# Altium schematic sheet 1_power.SchDoc(1_power)
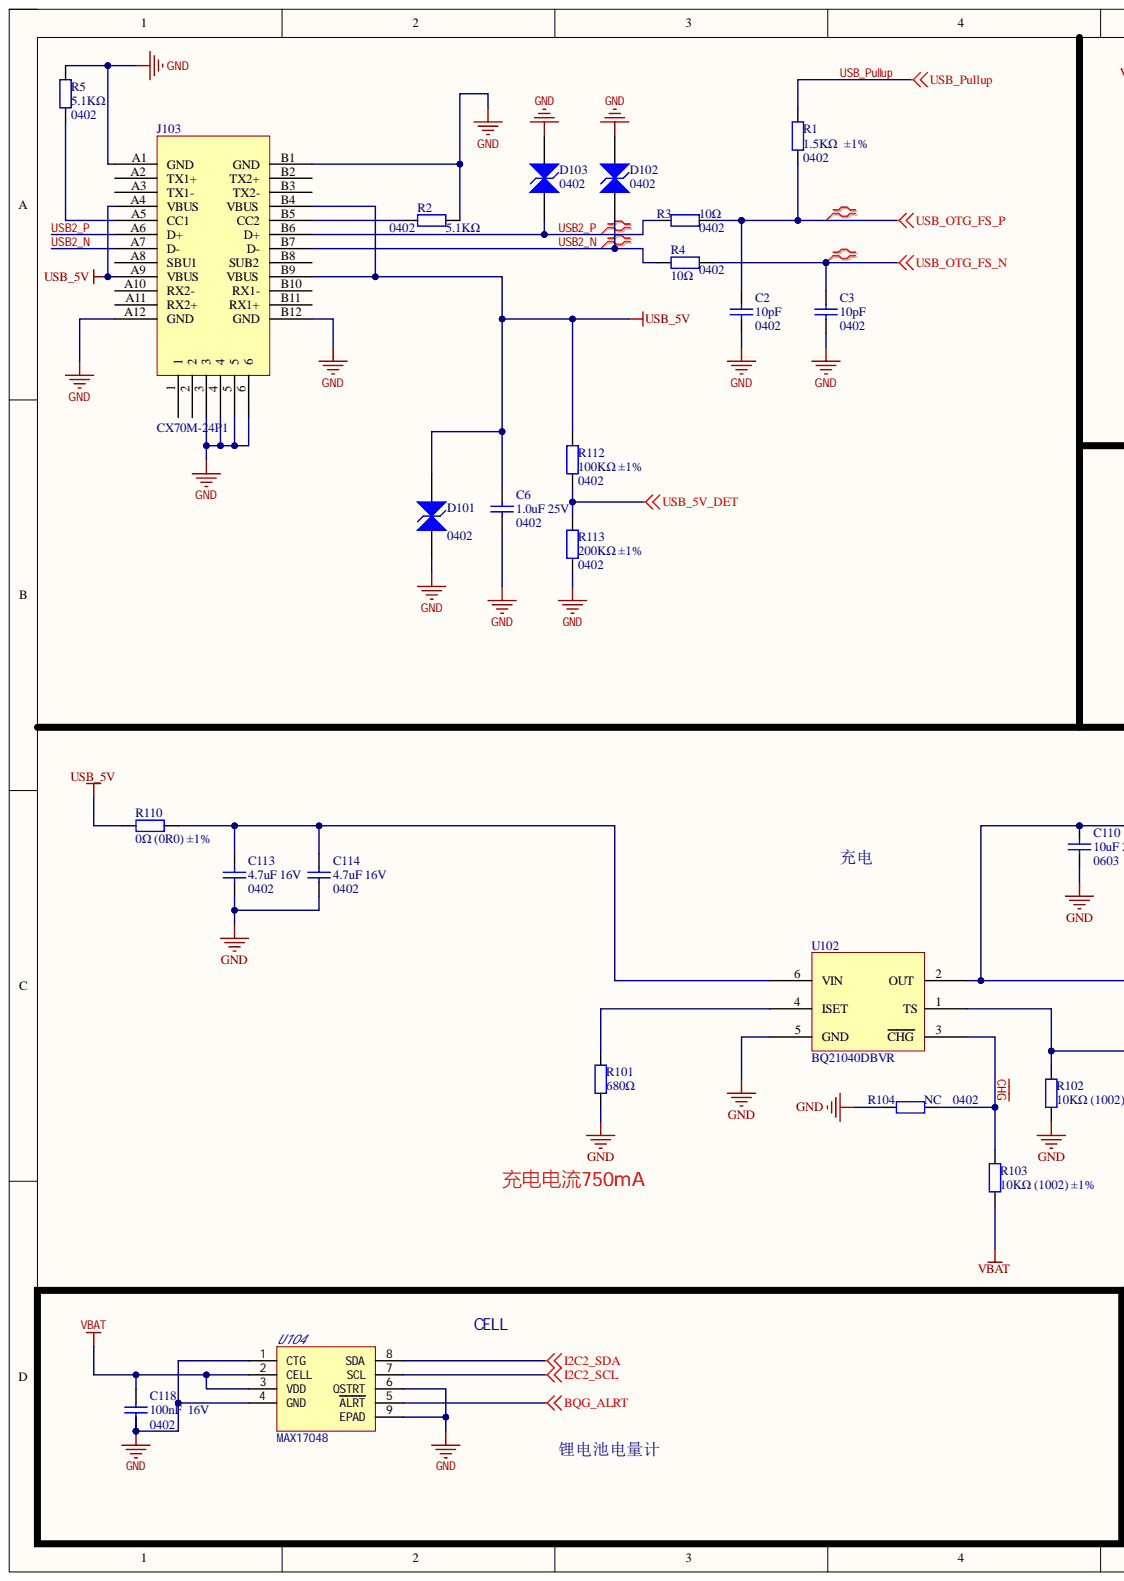
[diagram p0: 1_power.SchDoc, left]
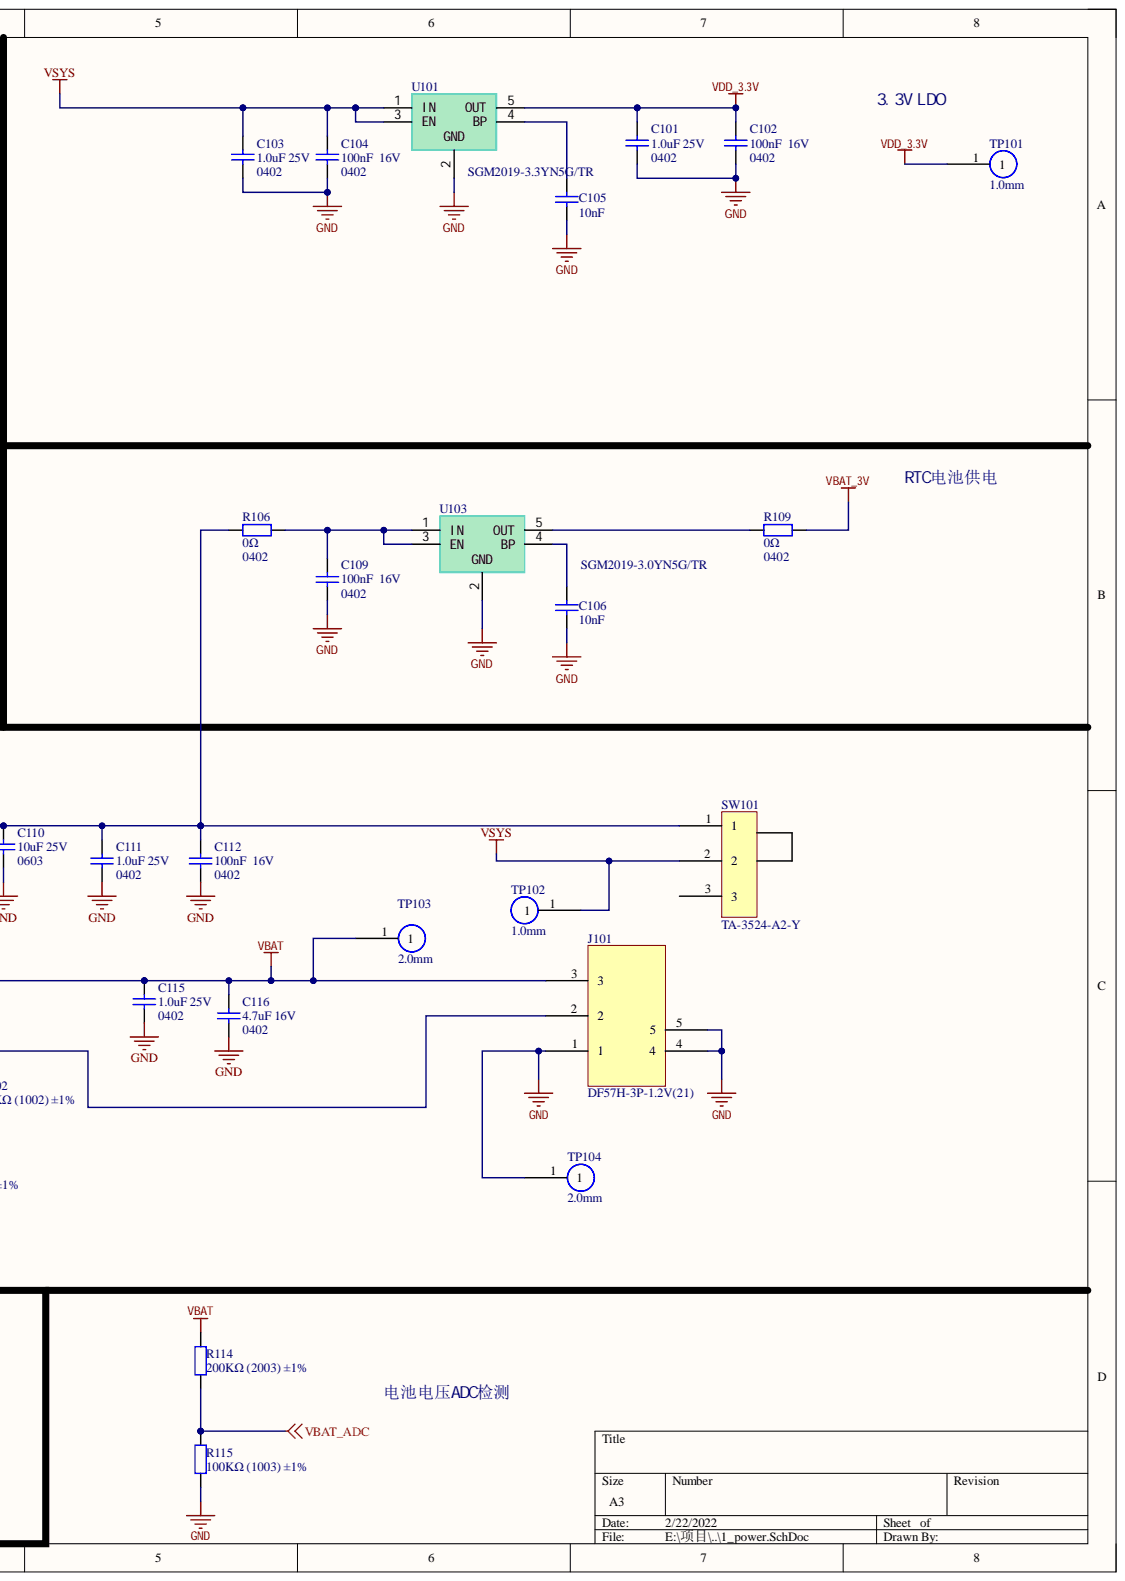
[diagram p1: 1_power.SchDoc, right]

## Parts
C2  10pF  Package: 0402  [0402_C]
C3  10pF  Package: 0402  [0402_C]
C6  1.0uF 25V  Package: 0402  [0402_C]
C101  1.0uF 25V  Package: 0402  [0402_C]
C102  100nF  16V  Package: 0402  [0402_C]
C103  1.0uF 25V  Package: 0402  [0402_C]
C104  100nF  16V  Package: 0402  [0402_C]
C105  10nF  [0402_C]
C106  10nF  [0402_C]
C109  100nF  16V  Package: 0402  [0402_C]
C110  10uF 25V  Package: 0603  [0603_C]
C111  1.0uF 25V  Package: 0402  [0402_C]
C112  100nF  16V  Package: 0402  [0402_C]
C113  4.7uF 16V  Package: 0402  [0402_C]
C114  4.7uF 16V  Package: 0402  [0402_C]
C115  1.0uF 25V  Package: 0402  [0402_C]
C116  4.7uF 16V  Package: 0402  [0402_C]
C118  100nF  16V  Package: 0402  [0402_C]
D101  Package: 0402  [DFN1006]  (hidden comment: RCLAMP0521P-N)
D102  Package: 0402  [DFN1006]  (hidden comment: RCLAMP0521P-N)
D103  Package: 0402  [DFN1006]  (hidden comment: RCLAMP0521P-N)
J101  DF57H-3P-1.2V(21)  [DF57H-3P-1.2V(21)]
J103  CX70M-24P1  [CX70M-24P1]
R1  1.5KΩ  ±1%  Package: 0402  [0402_R]
R2  5.1KΩ  Package: 0402  [0402_R]
R3  10Ω  Package: 0402  [0402_R]
R4  10Ω  Package: 0402  [0402_R]
R5  5.1KΩ  Package: 0402  [0402_R]
R101  680Ω  [0402_R]
R102  10KΩ (1002) ±1%  [0402_R]
R103  10KΩ (1002) ±1%  [0402_R]
R104  NC  Package: 0402  [0402_R]
R106  0Ω  Package: 0402  [0402_R]
R109  0Ω  Package: 0402  [0402_R]
R110  0Ω (0R0) ±1%  [0603_R]
R112  100KΩ ±1%  Package: 0402  [0402_R]
R113  200KΩ ±1%  Package: 0402  [0402_R]
R114  200KΩ (2003) ±1%  [0402_R]
R115  100KΩ (1003) ±1%  [0402_R]
SW101  TA-3524-A2-Y  [TA-3524-A2-Y]
TP101  1.0mm  [1mm测试点]
TP102  1.0mm  [1mm测试点]
TP103  2.0mm  [2mm测试点]
TP104  2.0mm  [2mm测试点]
U101  SGM2019-3.3YN5G/TR  [SGM2019-3.3YN5G/TR]
U102  BQ21040DBVR  [BQ21040DBVR]
U103  SGM2019-3.0YN5G/TR  [SGM2019-3.0YN5G/TR]
U104  MAX17048  [TDFN-10_EP]

## Nets
BQG_ALRT: U104.5(ALRT)
GND: C2.1 C3.1 C6.1 C101.1 C102.1 C103.1 C104.1 C105.1 C106.1 C109.1 C110.1 C111.1 C112.1 C113.1 C114.1 C115.1 C116.1 C118.1 D101.1 D102.1 D103.1 J101.1 J101.4 J101.5 J103.3 J103.4 J103.5 J103.6 J103.A1(GND) J103.A12(GND) J103.B1(GND) J103.B12(GND) R2.2 R5.2 R101.1 R102.1 R104.1 R113.1 R115.1 TP104.1 U101.2 U102.5(GND) U103.2 U104.1(CTG) U104.4(GND) U104.6(QSTRT) U104.9(EPAD)
I2C2_SCL: U104.7(SCL)
I2C2_SDA: U104.8(SDA)
USB_5V: C6.2 D101.2 J103.A4(VBUS) J103.A9(VBUS) J103.B4(VBUS) J103.B9(VBUS) R110.1 R112.2
USB_5V_DET: R112.1 R113.2
USB_OTG_FS_N: C3.2 R4.2
USB_OTG_FS_P: C2.2 R1.1 R3.2
VBAT: C110.2 C111.2 C112.2 C115.2 C116.2 C118.2 J101.3 R103.1 R106.1 R114.2 SW101.1 TP103.1 U102.2(OUT) U104.2(CELL) U104.3(VDD)
VBAT_3V: R109.2
VBAT_ADC: R114.1 R115.2
VDD_3.3V: C101.2 C102.2 TP101.1 U101.5(OUT)
VSYS: C103.2 C104.2 SW101.2 TP102.1 U101.1(IN) U101.3(EN)
~{CHG}: R103.2 R104.2 U102.3(CHG)
USB2_N: D102.2 J103.A7(D-) J103.B7(D-) R4.1
USB2_P: D103.2 J103.A6(D+) J103.B6(D+) R3.1
USB_Pullup: R1.2
NetC105_2: C105.2 U101.4(BP)
NetC106_2: C106.2 U103.4(BP)
NetC109_2: C109.2 R106.2 U103.1(IN) U103.3(EN)
NetC113_2: C113.2 C114.2 R110.2 U102.6(VIN)
NetJ101_2: J101.2 R102.2 U102.1(TS)
NetJ103_A5: J103.A5(CC1) R5.1
NetJ103_B5: J103.B5(CC2) R2.1
NetR101_2: R101.2 U102.4(ISET)
NetR109_1: R109.1 U103.5(OUT)

Not connected: J103 1 2 A2(TX1+) A3(TX1-) A8(SBU1) A10(RX2-) A11(RX2+) B2(TX2+) B3(TX2-) B8(SUB2) B10(RX1-) B11(RX1+); SW101 3

## Library data of the parts
C2 C3 = 0402 10pF (100) 5% 50V: 贴片电容; Component Kind: Standard; ComponentLink1Description: 供应商链接; ComponentLink1URL: http://www.szlcsc.com/product/details_33914.html; Library Name: Miscellaneous Devices LC.IntLib; Supplier: LC; SuppliersPartNumber: C32949
C6 C101 C103 C111 C115 = 0402 1.0uF (105) 10% 25V: 贴片电容; Component Kind: Standard; ComponentLink1Description: 供应商链接; ComponentLink1URL: http://www.szlcsc.com/product/details_53938.html; Library Name: Miscellaneous Devices LC.IntLib; Supplier: LC; SuppliersPartNumber: C52923
C102 C104 C109 C112 C118 = 0402 100nF (104) 10% 16V: 贴片电容; Component Kind: Standard; ComponentLink1Description: 供应商链接; ComponentLink1URL: http://www.szlcsc.com/product/details_1877.html; Library Name: Miscellaneous Devices LC.IntLib; Supplier: LC; SuppliersPartNumber: C1525
C105 C106 = 0402 10nF (103) 10% 50V: 贴片电容; Component Kind: Standard; ComponentLink1Description: 供应商链接; ComponentLink1URL: http://www.szlcsc.com/product/details_15869.html; Library Name: Miscellaneous Devices LC.IntLib; Package: 0402; Supplier: LC; SuppliersPartNumber: C15195
C110 = 0603 10uF 25: 贴片电容  0603 10uF 20% 25V; Component Kind: Standard; Library Name: AD_LIB.SchLib
C113 C114 C116 = 0402 4.7uF 16V: 贴片电容  0402 4.7uF 20% 16V; Component Kind: Standard; Library Name: AD_LIB.SchLib
D101-D103 = TVS_RCLAMP0521P-N: TVS  BORN伯恩半导体  RCLAMP0521P-N; Component Kind: Standard; ComponentLink1Description: 供应商链接; ComponentLink1URL: http://www.szlcsc.com/product/details_79530.html; Library Name: AD_LIB.SchLib; Supplier: LC; SuppliersPartNumber: C316043
R1 = 0402 1.5KΩ (1501) 1%: 贴片电阻; Component Kind: Standard; ComponentLink1Description: 供应商链接; ComponentLink1URL: http://www.szlcsc.com/product/details_26610.html; Library Name: Miscellaneous Devices LC.IntLib; Supplier: LC; SuppliersPartNumber: C25867
R2 R5 = 0402 5.1KΩ (5101) 1%: 贴片电阻; Component Kind: Standard; ComponentLink1Description: 供应商链接; ComponentLink1URL: http://www.szlcsc.com/product/details_26648.html; Library Name: Miscellaneous Devices LC.IntLib; Supplier: LC; SuppliersPartNumber: C25905
R3 R4 = 0402 10Ω (10R0) 1%: 贴片电阻; Component Kind: Standard; ComponentLink1Description: 供应商链接; ComponentLink1URL: http://www.szlcsc.com/product/details_25820.html; Library Name: Miscellaneous Devices LC.IntLib; Supplier: LC; SuppliersPartNumber: C25077
R101 = 0402 680Ω (6800) 1%: 贴片电阻; Component Kind: Standard; ComponentLink1Description: 供应商链接; ComponentLink1URL: http://www.szlcsc.com/product/details_25873.html; Library Name: Miscellaneous Devices LC.IntLib; Package: 0402; Supplier: LC; SuppliersPartNumber: C25130
R102 R103 = 0402 10KΩ (1002) 1%: 贴片电阻; Component Kind: Standard; ComponentLink1Description: 供应商链接; ComponentLink1URL: http://www.szlcsc.com/product/details_26487.html; Library Name: Miscellaneous Devices LC.IntLib; Package: 0402; Supplier: LC; SuppliersPartNumber: C25744
R104 = NC_0402贴片电阻: 贴片电阻; Component Kind: Standard; ComponentLink1Description: 供应商链接; ComponentLink1URL: http://www.szlcsc.com/product/details_26498.html; Library Name: AD_LIB.IntLib
R106 R109 = 0402 0Ω (0R0) 1%: 贴片电阻; Component Kind: Standard; ComponentLink1Description: 供应商链接; ComponentLink1URL: http://www.szlcsc.com/product/details_17853.html; Library Name: Miscellaneous Devices LC.IntLib; Supplier: LC; SuppliersPartNumber: C17168
R110 = 0603 0Ω (0R0) 1%: 贴片电阻; Component Kind: Standard; ComponentLink1Description: 供应商链接; ComponentLink1URL: http://www.szlcsc.com/product/details_21903.html; Library Name: Miscellaneous Devices LC.IntLib; Package: 0603; Supplier: LC; SuppliersPartNumber: C21189
R112 R115 = 0402 100KΩ (1003) 1%: 贴片电阻; Component Kind: Standard; ComponentLink1Description: 供应商链接; ComponentLink1URL: http://www.szlcsc.com/product/details_26484.html; Library Name: Miscellaneous Devices LC.IntLib; Supplier: LC; SuppliersPartNumber: C25741
  R115: Package: 0402
R113 R114 = 0402 200KΩ (2003) 1%: 贴片电阻; Component Kind: Standard; ComponentLink1Description: 供应商链接; ComponentLink1URL: http://www.szlcsc.com/product/details_26507.html; Library Name: Miscellaneous Devices LC.IntLib; Supplier: LC; SuppliersPartNumber: C25764
  R114: Package: 0402
SW101 = TA-3524-A2-Y: 品　　牌： Yuandi(台湾元迪)  厂家型号： TA-3524-A2-Y  商品编号： C842774  封装： SMD,6.6x2.7x2mm; Component Kind: Standard; Library Name: AD_LIB.SchLib
TP101 TP102 = 1.0mm测试点: Component Kind: Standard; Library Name: AD_LIB.IntLib
TP103 TP104 = 2.0mm测试点: Component Kind: Standard; Library Name: AD_LIB.IntLib
U101 = SGM2019-3.3YN5G/TR: 品　　牌： SGMICRO(圣邦微)  厂家型号： SGM2019-3.3YN5G/TR  商品编号： C23613  封装： SOT-23-5; Component Kind: Standard; Library Name: AD_LIB.SchLib
U102 = BQ21040DBVR: 品　　牌： TI(德州仪器)  厂家型号： BQ21040DBVR  商品编号： C202311  封装： SOT-23-6; Component Kind: Standard; Library Name: AD_LIB.SchLib
U103 = SGM2019-3.0YN5G/TR: 品　　牌： SGMICRO(圣邦微)  厂家型号： SGM2019-3.0YN5G/TR  商品编号： C57079  封装： SOT-23-5; Component Kind: Standard; Library Name: AD_LIB.SchLib
U104 = MAX17048: 电池电量计; Component Kind: Standard; Library Name: AD_LIB.SchLib
J101 = DF57H-3P-1.2V(21): Component Kind: Standard; Library Name: AD_LIB.SchLib
J103 = CX70M-24P1: Component Kind: Standard; Library Name: AD_LIB.SchLib
